# Altium schematic sheet Sheet2.SchDoc(Sheet2)
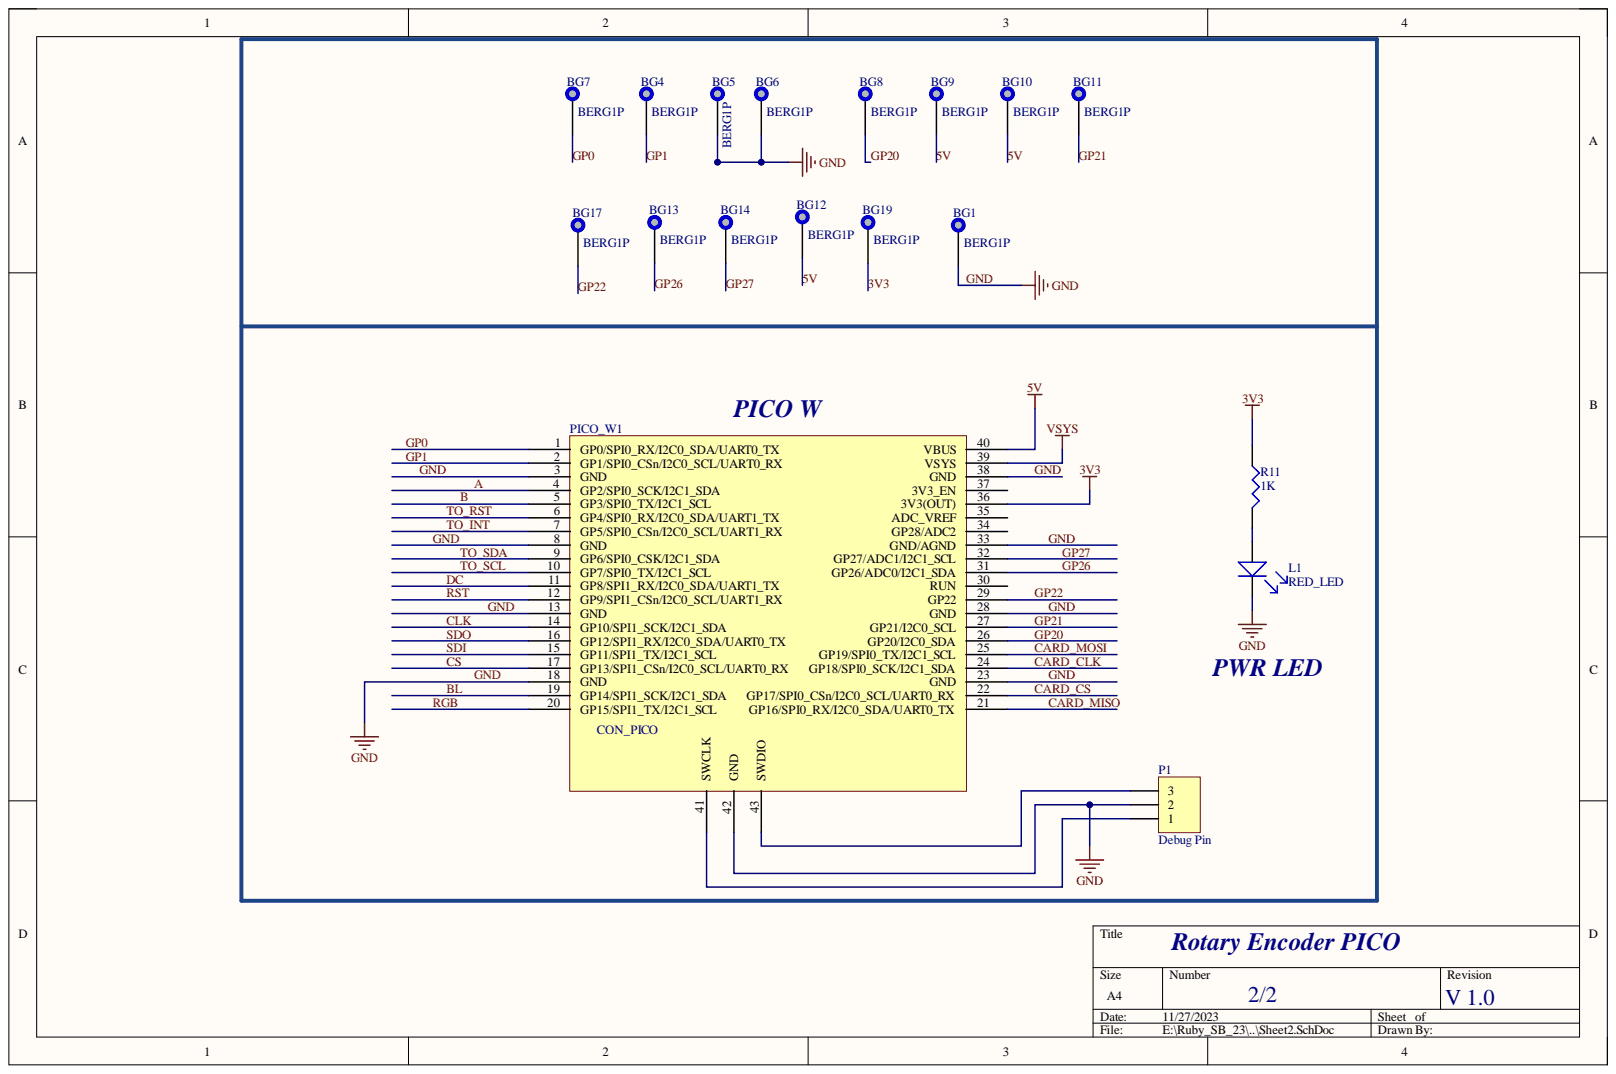
[diagram p0: Sheet2.SchDoc]

## Parts
BG1  BERG1P  [CLIP_]
BG4  BERG1P  [CLIP_]
BG5  BERG1P  [CLIP_]
BG6  BERG1P  [CLIP_]
BG7  BERG1P  [CLIP_]
BG8  BERG1P  [CLIP_]
BG9  BERG1P  [CLIP_]
BG10  BERG1P  [CLIP_]
BG11  BERG1P  [CLIP_]
BG12  BERG1P  [CLIP_]
BG13  BERG1P  [CLIP_]
BG14  BERG1P  [CLIP_]
BG17  BERG1P  [CLIP_]
BG19  BERG1P  [CLIP_]
L1  RED_LED  [LED_0603]
P1  Debug Pin  [SMD_3P BERG]
PICO_W1  CON_PICO  [PICO_W]
R11  1K  [R_0603]

## Nets
VSYS: PICO_W1.39(VSYS)
3V3: BG19.1 PICO_W1.36(3V3(OUT)) R11.1
5V: BG9.1 BG10.1 BG12.1 PICO_W1.40(VBUS)
A: PICO_W1.4(GP2/SPI0_SCK/I2C1_SDA)
B: PICO_W1.5(GP3/SPI0_TX/I2C1_SCL)
BL: PICO_W1.19(GP14/SPI1_SCK/I2C1_SDA)
CARD_CLK: PICO_W1.24(GP18/SPI0_SCK/I2C1_SDA)
CARD_CS: PICO_W1.22(GP17/SPI0_CSn/I2C0_SCL/UART0_RX)
CARD_MISO: PICO_W1.21(GP16/SPI0_RX/I2C0_SDA/UART0_TX)
CARD_MOSI: PICO_W1.25(GP19/SPI0_TX/I2C1_SCL)
CLK: PICO_W1.14(GP10/SPI1_SCK/I2C1_SDA)
CS: PICO_W1.17(GP13/SPI1_CSn/I2C0_SCL/UART0_RX)
DC: PICO_W1.11(GP8/SPI1_RX/I2C0_SDA/UART1_TX)
GND: BG1.1 BG5.1 BG6.1 L1.K P1.2 PICO_W1.3(GND) PICO_W1.8(GND) PICO_W1.13(GND) PICO_W1.18(GND) PICO_W1.23(GND) PICO_W1.28(GND) PICO_W1.33(GND/AGND) PICO_W1.38(GND) PICO_W1.42(GND)
GP0: BG7.1 PICO_W1.1(GP0/SPI0_RX/I2C0_SDA/UART0_TX)
GP1: BG4.1 PICO_W1.2(GP1/SPI0_CSn/I2C0_SCL/UART0_RX)
GP20: BG8.1 PICO_W1.26(GP20/I2C0_SDA)
GP21: BG11.1 PICO_W1.27(GP21/I2C0_SCL)
GP22: BG17.1 PICO_W1.29(GP22)
GP26: BG13.1 PICO_W1.31(GP26/ADC0/I2C1_SDA)
GP27: BG14.1 PICO_W1.32(GP27/ADC1/I2C1_SCL)
RGB: PICO_W1.20(GP15/SPI1_TX/I2C1_SCL)
RST: PICO_W1.12(GP9/SPI1_CSn/I2C0_SCL/UART1_RX)
SDI: PICO_W1.15(GP11/SPI1_TX/I2C1_SCL)
SDO: PICO_W1.16(GP12/SPI1_RX/I2C0_SDA/UART0_TX)
TO_INT: PICO_W1.7(GP5/SPI0_CSn/I2C0_SCL/UART1_RX)
TO_RST: PICO_W1.6(GP4/SPI0_RX/I2C0_SDA/UART1_TX)
TO_SCL: PICO_W1.10(GP7/SPI0_TX/I2C1_SCL)
TO_SDA: PICO_W1.9(GP6/SPI0_CSK/I2C1_SDA)
NetL1_A: L1.A R11.2
NetP1_1: P1.1 PICO_W1.41(SWCLK)
NetP1_3: P1.3 PICO_W1.43(SWDIO)

Not connected: PICO_W1 30(RUN) 34(GP28/ADC2) 35(ADC_VREF) 37(3V3_EN)

## Library data of the parts
BG1 BG4-BG14 BG17 BG19 = TESTPOINT: Component Kind: Standard; Library Name: MIX.SchLib
L1 = LED: Component Kind: Standard; Library Name: dxp_pasl_lib.IntLib
P1 = Header 3: Header, 3-Pin; Component Kind: Standard; LatestRevisionDate: 17-Jul-2002; LatestRevisionNote: Re-released for DXP Platform.; Library Name: Miscellaneous Connectors.IntLib; Signal Integrity: Connector; Publisher: Altium Limited
PICO_W1 = PICO W: Component Kind: Standard; Library Name: MIX.SchLib
R11 = RES: Component Kind: Standard; Library Name: dxp_pasl_lib.IntLib
